# Altium schematic sheet hip4081_testboard.SchDoc
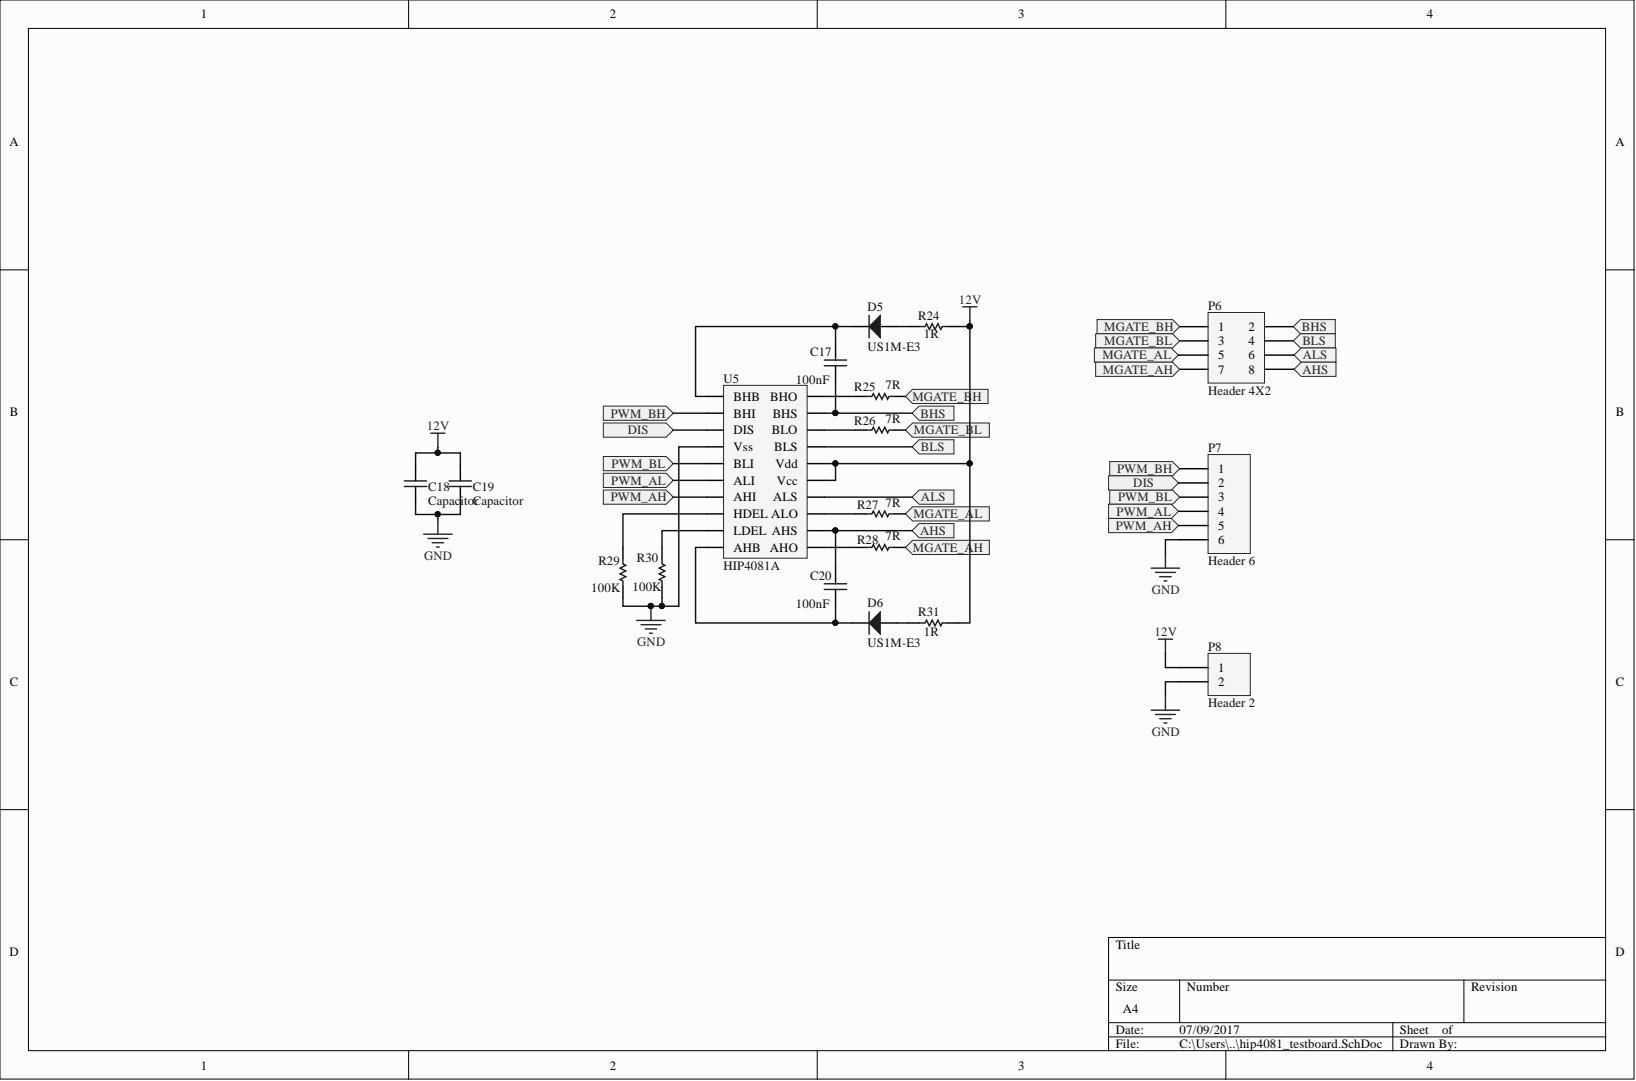
[diagram p0: hip4081_testboard.SchDoc]

## Parts
C17  Capacitor  value 100nF  [0805]
C18  Capacitor  [0805]
C19  Capacitor  [0805]
C20  Capacitor  value 100nF  [0805]
D5  US1M-E3  [DO-214AC]
D6  US1M-E3  [DO-214AC]
P6  Header 4X2  [HDR2X4]
P7  Header 6  [HDR1X6]
P8  Header 2  [HDR1X2]
R24  value 1R  [RWS3]  (hidden comment: Resistor)
R25  value 7R  [0805]  (hidden comment: Resistor)
R26  value 7R  [0805]  (hidden comment: Resistor)
R27  value 7R  [0805]  (hidden comment: Resistor)
R28  value 7R  [0805]  (hidden comment: Resistor)
R29  value 100K  [0805]  (hidden comment: Resistor)
R30  value 100K  [0805]  (hidden comment: Resistor)
R31  value 1R  [RWS3]  (hidden comment: Resistor)
U5  HIP4081A  [SOIC-20 WB]

## Ports
AHS, ALS, BHS, BLS, DIS, MGATE_AH, MGATE_AL, MGATE_BH, MGATE_BL, PWM_AH, PWM_AL, PWM_BH, PWM_BL

## Nets
12V: C18.2 C19.2 P8.1 R24.2 R31.2 U5.15(Vcc) U5.16(Vdd)
GND: C18.1 C19.1 P7.6 P8.2 R29.1 R30.1 U5.4(Vss)
AHS: C20.2 P6.8 U5.12(AHS) ; port AHS
ALS: P6.6 U5.14(ALS) ; port ALS
BHS: C17.1 P6.2 U5.19(BHS) ; port BHS
BLS: P6.4 U5.17(BLS) ; port BLS
DIS: P7.2 U5.3(DIS) ; port DIS
MGATE_AH: P6.7 R28.2 ; port MGATE_AH
MGATE_AL: P6.5 R27.2 ; port MGATE_AL
MGATE_BH: P6.1 R25.2 ; port MGATE_BH
MGATE_BL: P6.3 R26.2 ; port MGATE_BL
PWM_AH: P7.5 U5.7(AHI) ; port PWM_AH
PWM_AL: P7.4 U5.6(ALI) ; port PWM_AL
PWM_BH: P7.1 U5.2(BHI) ; port PWM_BH
PWM_BL: P7.3 U5.5(BLI) ; port PWM_BL
NetC17_2: C17.2 D5.2 U5.1(BHB)
NetC20_1: C20.1 D6.2 U5.10(AHB)
NetD5_1: D5.1 R24.1
NetD6_1: D6.1 R31.1
NetR25_1: R25.1 U5.20(BHO)
NetR26_1: R26.1 U5.18(BLO)
NetR27_1: R27.1 U5.13(ALO)
NetR28_1: R28.1 U5.11(AHO)
NetR29_2: R29.2 U5.8(HDEL)
NetR30_2: R30.2 U5.9(LDEL)

## Library data of the parts
P6 = Header 4X2: Header, 4-Pin, Dual row; Component Kind: Standard; LatestRevisionDate: 17-Jul-2002; LatestRevisionNote: Re-released for DXP Platform.; Library Name: Miscellaneous Connectors.IntLib; Signal Integrity: Connector; Publisher: Altium Limited
P7 = Header 6: Header, 6-Pin; Component Kind: Standard; LatestRevisionDate: 17-Jul-2002; LatestRevisionNote: Re-released for DXP Platform.; Library Name: Miscellaneous Connectors.IntLib; Signal Integrity: Connector; Publisher: Altium Limited
P8 = Header 2: Header, 2-Pin; Component Kind: Standard; LatestRevisionDate: 17-Jul-2002; LatestRevisionNote: Re-released for DXP Platform.; Library Name: Miscellaneous Connectors.IntLib; Signal Integrity: Connector; Publisher: Altium Limited
C17-C20 = Capacitor: Component Kind: Standard; Library Name: sdu_embedded.SchLib
D5 D6 = US1M-E3: Component Kind: Standard; Library Name: sdu_embedded.SchLib
R24-R31 = Resistor: Component Kind: Standard; Library Name: sdu_embedded.SchLib
U5 = HIP4081A: Component Kind: Standard; Library Name: sdu_embedded.SchLib
